# Altium schematic sheet LIDO-HW.100.InputStage_2.SchDoc(LIDO-HW.100.InputStage_2)
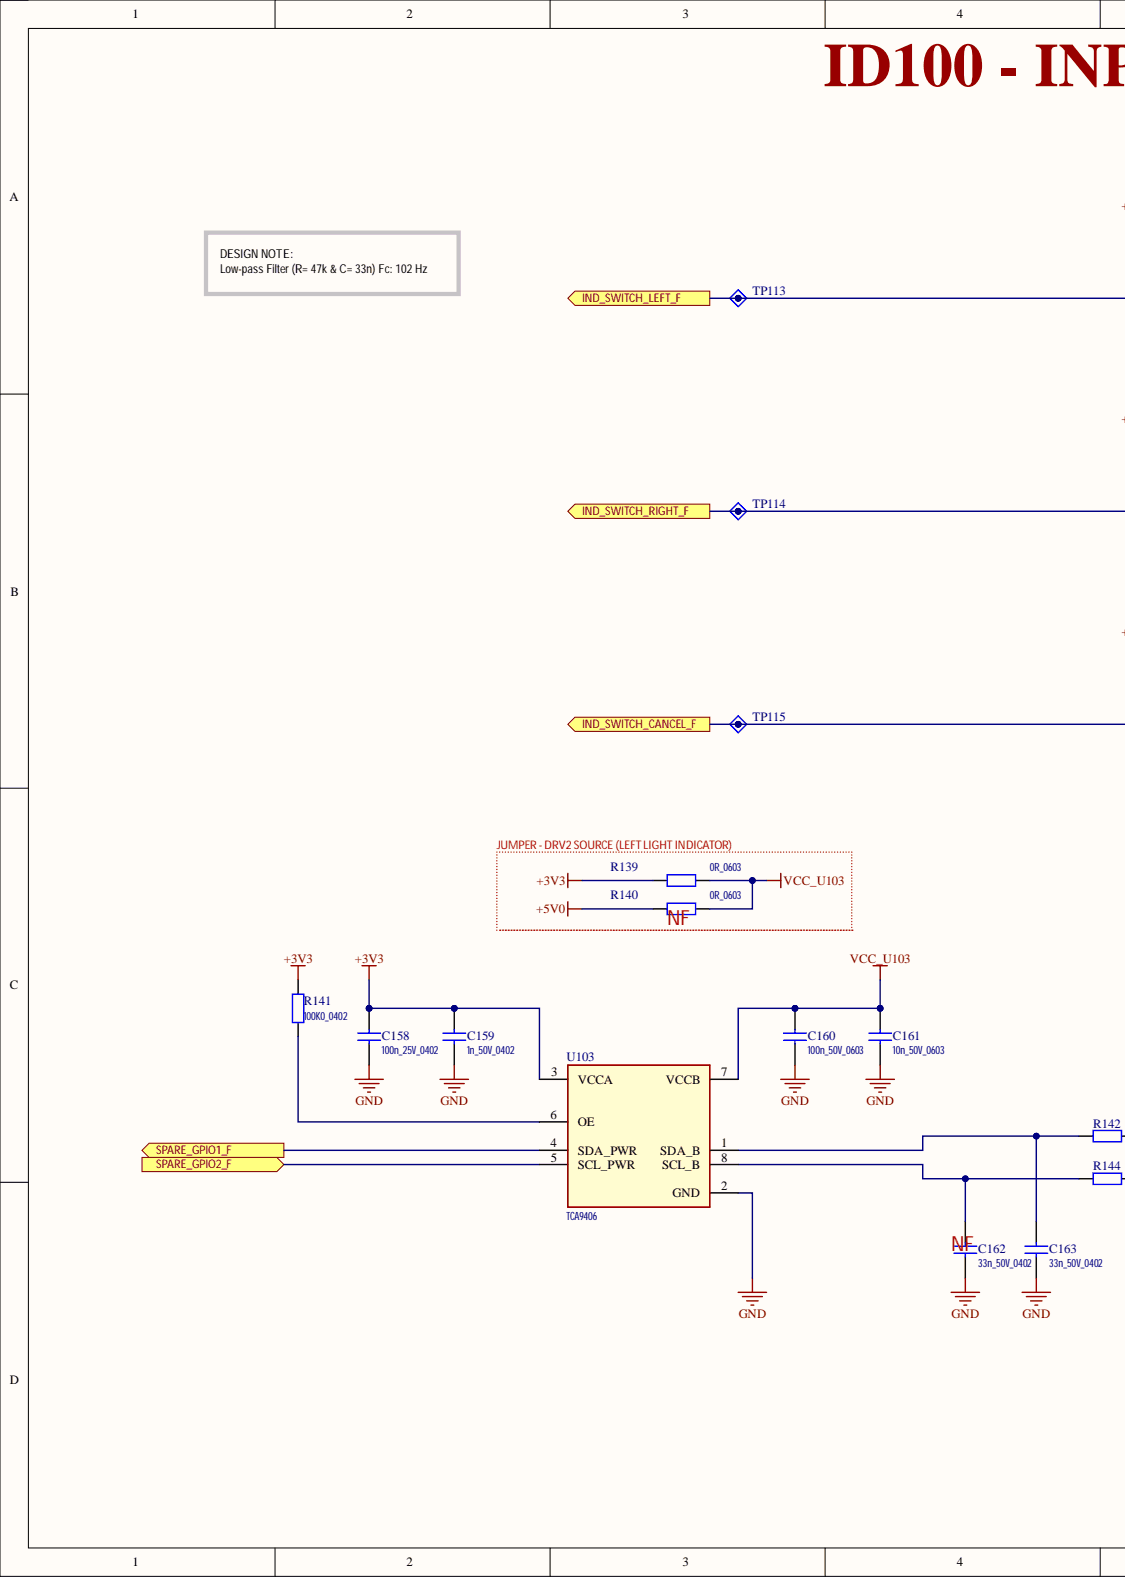
[diagram p0: LIDO-HW.100.InputStage_2.SchDoc, left]
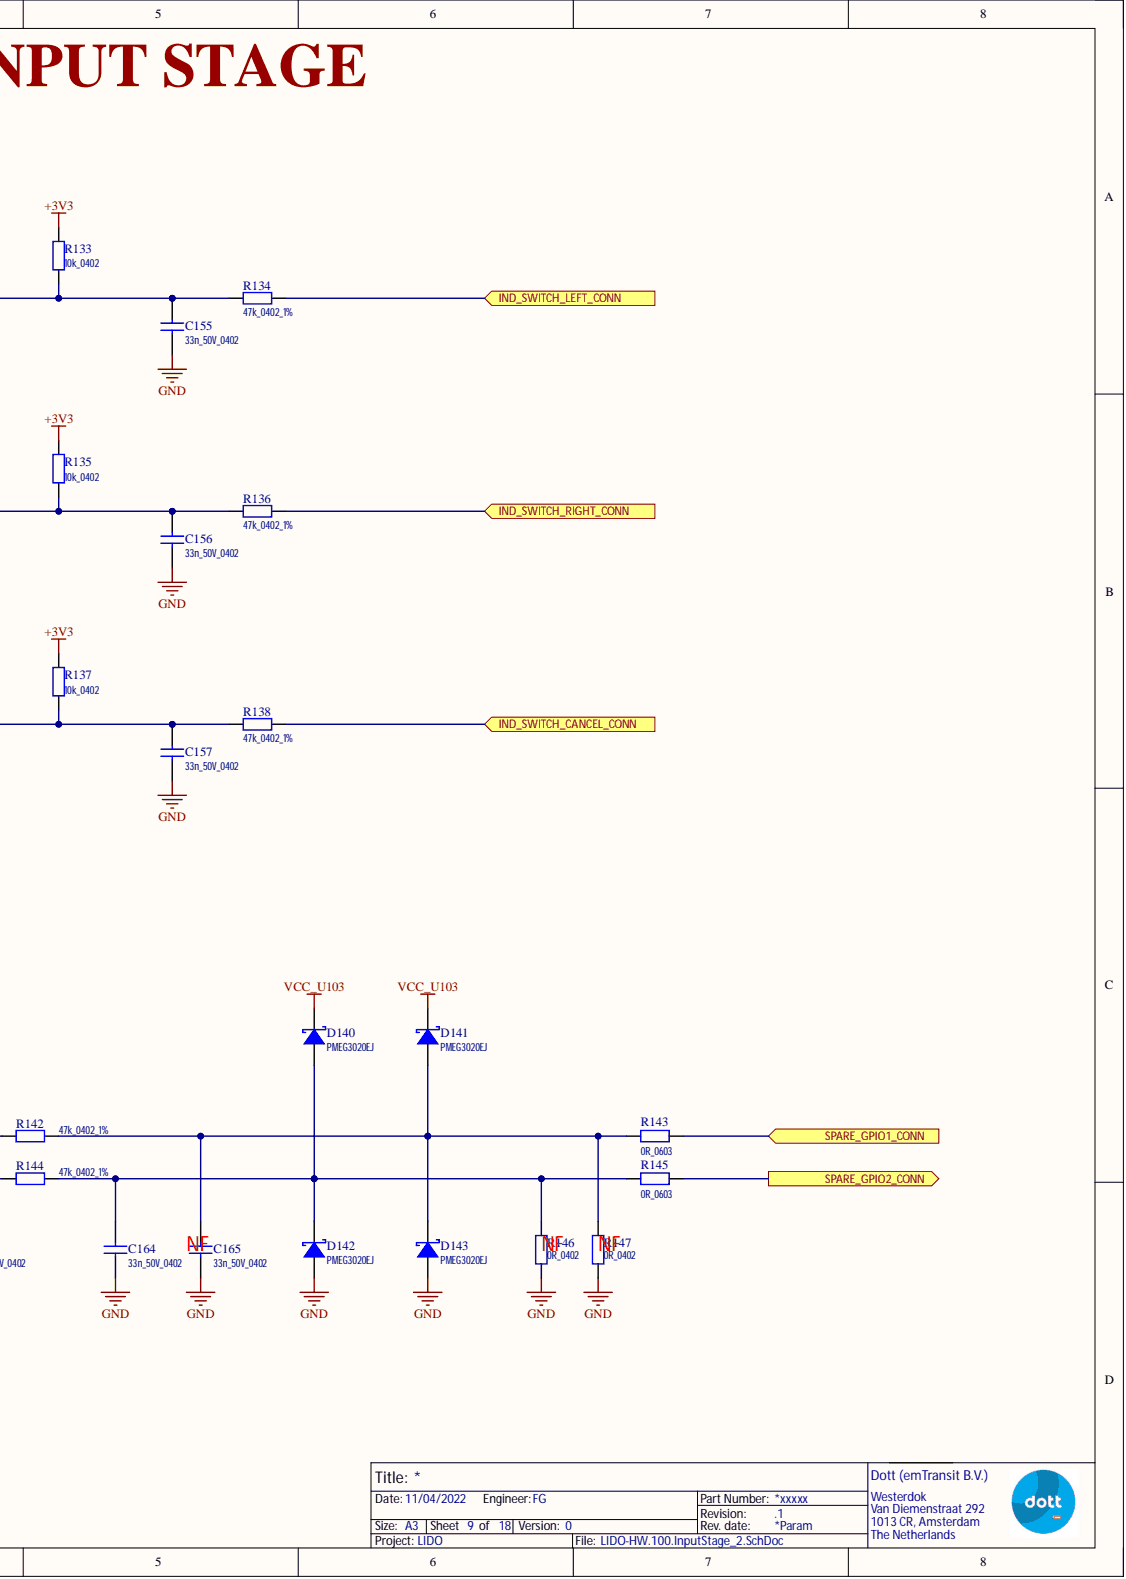
[diagram p1: LIDO-HW.100.InputStage_2.SchDoc, right]

## Parts
C155  33n_50V_0402
C156  33n_50V_0402
C157  33n_50V_0402
C158  100n_25V_0402
C159  1n_50V_0402
C160  100n_50V_0603
C161  10n_50V_0603
C162  33n_50V_0402
C163  33n_50V_0402
C164  33n_50V_0402
C165  33n_50V_0402
D140  PMEG3020EJ
D141  PMEG3020EJ
D142  PMEG3020EJ
D143  PMEG3020EJ
R133  10k_0402
R134  47k_0402_1%
R135  10k_0402
R136  47k_0402_1%
R137  10k_0402
R138  47k_0402_1%
R139  0R_0603
R140  0R_0603
R141  100K0_0402
R142  47k_0402_1%
R143  0R_0603
R144  47k_0402_1%
R145  0R_0603
R146  0R_0402
R147  0R_0402
TP113  TestPoint_PWR  (value not drawn)  (no BOM)
TP114  TestPoint_PWR  (value not drawn)  (no BOM)
TP115  TestPoint_PWR  (value not drawn)  (no BOM)
U103  TCA9406

## Ports
IND_SWITCH_CANCEL_CONN, IND_SWITCH_CANCEL_F, IND_SWITCH_LEFT_CONN, IND_SWITCH_LEFT_F, IND_SWITCH_RIGHT_CONN, IND_SWITCH_RIGHT_F, SPARE_GPIO1_CONN, SPARE_GPIO1_F, SPARE_GPIO2_CONN, SPARE_GPIO2_F

## Nets
+3V3: C158.1 C159.1 R133.2 R135.2 R137.2 R139.1 R141.1 U103.3(VCCA)
+5V0: R140.1
GND: C155.2 C156.2 C157.2 C158.2 C159.2 C160.2 C161.2 C162.2 C163.2 C164.2 C165.2 D142.A D143.A R146.2 R147.2 U103.2(GND)
VCC_U103: C160.1 C161.1 D140.C D141.C R139.2 R140.2 U103.7(VCCB)
IND_SWITCH_CANCEL_CONN: R138.2 ; port IND_SWITCH_CANCEL_CONN
IND_SWITCH_CANCEL_F: C157.1 R137.1 R138.1 TP115.1 ; port IND_SWITCH_CANCEL_F
IND_SWITCH_LEFT_CONN: R134.2 ; port IND_SWITCH_LEFT_CONN
IND_SWITCH_LEFT_F: C155.1 R133.1 R134.1 TP113.1 ; port IND_SWITCH_LEFT_F
IND_SWITCH_RIGHT_CONN: R136.2 ; port IND_SWITCH_RIGHT_CONN
IND_SWITCH_RIGHT_F: C156.1 R135.1 R136.1 TP114.1 ; port IND_SWITCH_RIGHT_F
SPARE_GPIO1_CONN: R143.2 ; port SPARE_GPIO1_CONN
SPARE_GPIO1_F: U103.4(SDA_PWR) ; port SPARE_GPIO1_F
SPARE_GPIO2_CONN: R145.2 ; port SPARE_GPIO2_CONN
SPARE_GPIO2_F: U103.5(SCL_PWR) ; port SPARE_GPIO2_F
NetC162_1: C162.1 R144.1 U103.8(SCL_B)
NetC163_1: C163.1 R142.1 U103.1(SDA_B)
NetC164_1: C164.1 D140.A D142.C R144.2 R145.1 R146.1
NetC165_1: C165.1 D141.A D143.C R142.2 R143.1 R147.1
NetR141_2: R141.2 U103.6(OE)

## Library data of the parts
C155-C165 = Ceramic: Ceramic CAP Symbol; Component Kind: Standard; Library Name: 01_Capacitors.SchLib
D140-D143 = Schottky_A: Schottky Diode Symbol; Component Kind: Standard; Library Name: 06_Diodes.SchLib
R133-R147 = Resistor: Resistor Symbol; Component Kind: Standard; Library Name: 02_Resistors.SchLib
TP113-TP115 = TestPoint_A: Test Point Symbol verion A; 00_Name: TP_XXmm; 01_Diameter: 1mm; Library Name: 31_MFG_Elements.SchLib
U103 = TCA9406_A: 2-Bit Bidirectional 1-MHz, I  2C Bus and SMBus Voltage-Level Translator With 8-kV HBM ESD; Component Kind: Standard; Library Name: 15_IC_Logic.SchLib
